# Altium schematic sheet PWR_REG_LED_Sheet.SchDoc
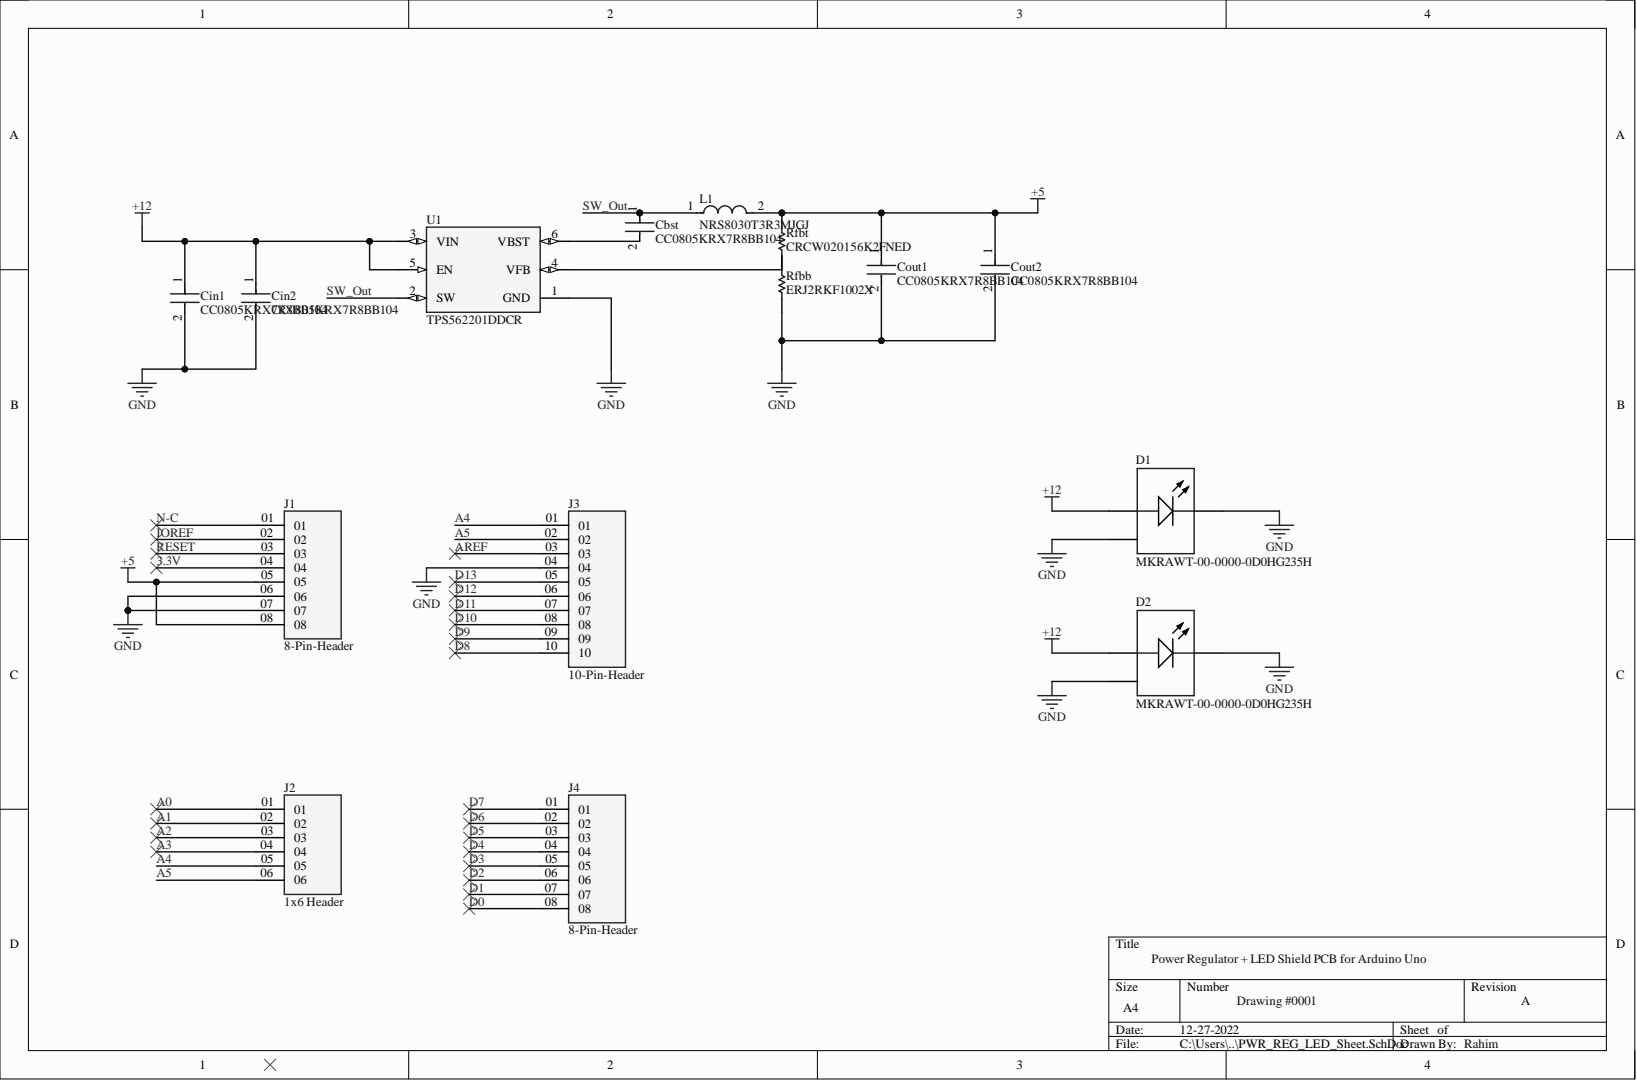
[diagram p0: PWR_REG_LED_Sheet.SchDoc]

## Parts
Cbst  CC0805KRX7R8BB104  [FP-CC0805-0_85-MFG]
Cin1  CC0805KRX7R8BB104  [FP-CC0805-0_85-MFG]
Cin2  CC0805KRX7R8BB104  [FP-CC0805-0_85-MFG]
Cout1  CC0805KRX7R8BB104  [FP-CC0805-0_85-MFG]
Cout2  CC0805KRX7R8BB104  [FP-CC0805-0_85-MFG]
D1  MKRAWT-00-0000-0D0HG235H  [CREE-MK-R-2_V]
D2  MKRAWT-00-0000-0D0HG235H  [CREE-MK-R-2_V]
J1  8-Pin-Header  [SAMTEC_SSQ-108-03-X-S]
J2  1x6 Header  [SAMTEC_SSQ-106-03-X-S]
J3  10-Pin-Header  [SAMTEC_SSQ-110-03-X-S]
J4  8-Pin-Header  [SAMTEC_SSQ-108-03-X-S]
L1  NRS8030T3R3MJGJ  [FP-NRS8030-MFG]
Rfbb  ERJ2RKF1002X  [RESC1005X40X25LL05T05]
Rfbt  CRCW020156K2FNED  [RESC0603X28X15NL05T05]
U1  TPS562201DDCR  [FP-DDC0006A-IPC_C]

## Nets
+5: Cout1.1 Cout2.1 J1.05 J1.08 L1.2 Rfbt.2
+12: Cin1.1 Cin2.1 D1.1 D2.1 U1.3(VIN) U1.5(EN)
GND: Cin1.2 Cin2.2 Cout1.2 Cout2.2 D1.2 D1.3 D2.2 D2.3 J1.06 J1.07 J3.04 Rfbb.1 U1.1(GND)
3.3V: J1.04
A0: J2.01
A1: J2.02
A2: J2.03
A3: J2.04
A4: J2.05 J3.01
A5: J2.06 J3.02
AREF: J3.03
D0: J4.08
D1: J4.07
D2: J4.06
D3: J4.05
D4: J4.04
D5: J4.03
D6: J4.02
D7: J4.01
D8: J3.10
D9: J3.09
D10: J3.08
D11: J3.07
D12: J3.06
D13: J3.05
IOREF: J1.02
N-C: J1.01
RESET: J1.03
SW_Out: Cbst.1 L1.1 U1.2(SW)
NetCbst_2: Cbst.2 U1.6(VBST)
NetRfbt_1: Rfbt.1 U1.4(VFB)

Not connected: Rfbb 2

## Library data of the parts
Cbst Cin1 Cin2 Cout1 Cout2 = CMP-1036-04410-2: CAP CER 0.1UF 25V X7R 0805; Manufacturer: Yageo; Capacitance: 100 nF; Tolerance: 0.1; Value: 100 nF; Automotive: No; Category: Cap; Component Kind: Standard; ComponentLink2Description: Datasheet; ComponentLink2URL: http://www.yageo.com/documents/recent/UPY-GPHC_Y5V_6.3V-to-50V_8.pdf; Device Class L1: Passive Components; Device Class L2: Capacitors; Device Class L3: Ceramic Capacitors; Digikey Description: CAP CER 0.1UF 25V X7R 0805; Footprint Url: http://www.yageo.com/exep/pages/download/literatures/UPY-C_GEN_24.pdf; Height: 0.95mm; Ipc Land Pattern Name: CAPC200125X85; Lead Free: Yes; Library Name: Altium Content Vault; Manufacturer Part Number: CC0805KRX7R8BB104; Material: Ceramic; Mouser Description: Multilayer Ceramic Capacitors MLCC - SMD/SMT 100nF 25V X7R 10%; Octopart: https://octopart.com/cc0805krx7r8bb104-yageo-39467766; Package: CC0805-0.85; RoHS: TRUE; Temperature: -30°C to +85°C; Temperature Characteristic: X7R; Temperature Range High: +85°C; Temperature Range Low: -30°C; Voltage Rating: 25 V
D1 D2 = CMP-2100-00977-1: LED, White, 12 V, 2-Pin SMD, RoHS, Tape and Reel; Component Kind: Standard; Library Name: Altium Content Vault
J1 J4 = SSQ-108-03-X-S: 8 Position Receptacle Connector Through Hole, Right Angle; MF: Samtec; MP: SSQ-108-03-L-S; Availability: Bad; Check_prices: https://www.snapeda.com/parts/SSQ-108-03-L-S/Samtec/view-part/3352745/?ref=eda; Component Kind: Standard; Library Name: SSQ-108-03-X-S.IntLib; Package: None; Price: None; Purchase-URL: https://pricing.snapeda.com/search/part/SSQ-108-03-L-S/?ref=eda; SnapEDA_Link: https://www.snapeda.com/parts/SSQ-108-03-L-S/Samtec/view-part/3352745/?ref=snap
J2 = SSQ-106-03-X-S: 6 Position Receptacle Connector Through Hole; MF: Samtec; MP: SSQ-106-03-L-S; Availability: Bad; Check_prices: https://www.snapeda.com/parts/SSQ-106-03-L-S/Samtec/view-part/3331053/?ref=eda; Component Kind: Standard; Library Name: SSQ-106-03-X-S.IntLib; Package: None; Price: None; Purchase-URL: https://pricing.snapeda.com/search/part/SSQ-106-03-L-S/?ref=eda; SnapEDA_Link: https://www.snapeda.com/parts/SSQ-106-03-L-S/Samtec/view-part/3331053/?ref=snap
J3 = SSQ-110-03-X-S: 10 Position Receptacle Connector Through Hole, Right Angle; MF: Samtec; MP: SSQ-110-03-L-S; Availability: Bad; Check_prices: https://www.snapeda.com/parts/SSQ-110-03-L-S/Samtec/view-part/3589021/?ref=eda; Component Kind: Standard; Library Name: SSQ-110-03-X-S.IntLib; Package: None; Price: None; Purchase-URL: https://pricing.snapeda.com/search/part/SSQ-110-03-L-S/?ref=eda; SnapEDA_Link: https://www.snapeda.com/parts/SSQ-110-03-L-S/Samtec/view-part/3589021/?ref=snap
L1 = CMP-14484-000405-1: Inductor Power Shielded Wirewound 3.3uH 20% 100KHz Ferrite 4.2A 24.7mΩ DCR T/R; Current Rating: 4.3A; DC Resistance (DCR): 19mR; Self Resonant Frequency: 50MHz; Case/Package: Nonstandard; Component Kind: Standard; Core Material: Ferrite; Depth: 8.2mm; Halogen Free: Halogen Free; Height: 3mm; Height - Seated (Max): 0.118inch; Inductance: 3.3uH; Inductance Tolerance: 20%; Lead Free: Lead Free; Lead Pitch: 5.6mm; Length: 8mm; Library Name: Altium Content Vault; Max DC Current: 4.2A; Max Operating Temperature: 125°C; Min Operating Temperature: -25°C; Mount: Surface Mount; Number of Pins: 2; Package Quantity: 1000; Packaging: Tape and Reel; Radiation Hardening: No; REACH SVHC: No SVHC; Resistor Type: Wirewound; RMS Current (Irms): 4.3A; RoHS Compliant: Yes; Saturation Current: 4.2A; Series: NRS; Shielding: Shielded; Termination: SMD/SMT; Test Frequency: 100kHz; Tolerance: 20%; Width: 0.315inch
Rfbb = CMP-2000-07588-1: Chip Resistor, 10 KOhm, +/- 1%, 0.1 W, -55 to 155 degC, 0402 (1005 Metric), RoHS, Tape and Reel; Manufacturer: Panasonic; Case\/Package: 0402; Max Power Dissipation: 100mW; Resistance: 10KOhm; Temperature Coefficient: 100ppm\/degreesC; Voltage Rating: 50V; Case Code (Imperial): 0402; Case Code (Metric): 1005; Component Kind: Standard; Composition: ThickFilm; Contact Plating: Tin; Datasheet URL: https://industrial.panasonic.com/cdbs/www-data/pdf/RDA0000/AOA0000C304.pdf; Datasheet Version: 02/2017; Depth: 0.5mm; Height: 0.35mm; Lead Free: LeadFree; Length: 1mm; Library Name: Altium Content Vault; Manufacturer URL: http://www.panasonic.com/; Max Operating Temperature: 155degC; Military Standard: Not; Min Operating Temperature: -55degC; Mount: SurfaceMount; Mounting Technology: SurfaceMount; Number of Pins: 2; Number of Terminations: 2; Package Description: 2-Pin Surface Mount Device, Body 1 x 0.5 mm; Package Quantity: 1; Package Reference: 402; Packaging: TapeandReel; Power Rating: 100mW; Radiation Hardening: No; REACH SVHC: NoSVHC; RoHSCompliant: True; Termination: SMD/SMT; Tolerance: 1%; Voltage Rating (DC): 50V; Width: 0.02inch
Rfbt = CMP-2004-00723-1: Manufacturer: Vishay Dale; Component Kind: Standard; Library Name: Altium Content Vault; Part Number: CRCW020156K2FNED
U1 = CMP-04918-000361-1: IC REG BUCK ADJ 2A TSOT23-6; Max Input Voltage: 17V; Min Input Voltage: 4.5V; Number of Outputs: 1; Output Type: Adjustable; Output Voltage: 7V; Quiescent Current: 400uA; Switching Frequency: 580kHz; Case/Package: SOT; Component Kind: Standard; Contact Plating: Tin; Lead Free: Lead Free; Library Name: Altium Content Vault; Max Operating Temperature: 125°C; Max Output Current: 2A; Min Operating Temperature: -40°C; Mount: Surface Mount; Number of Pins: 6; Operating Supply Voltage: 17V; RoHS Compliant: Yes
